# Altium schematic sheet CPU - USB, PCIE, ETHERNET.SchDoc
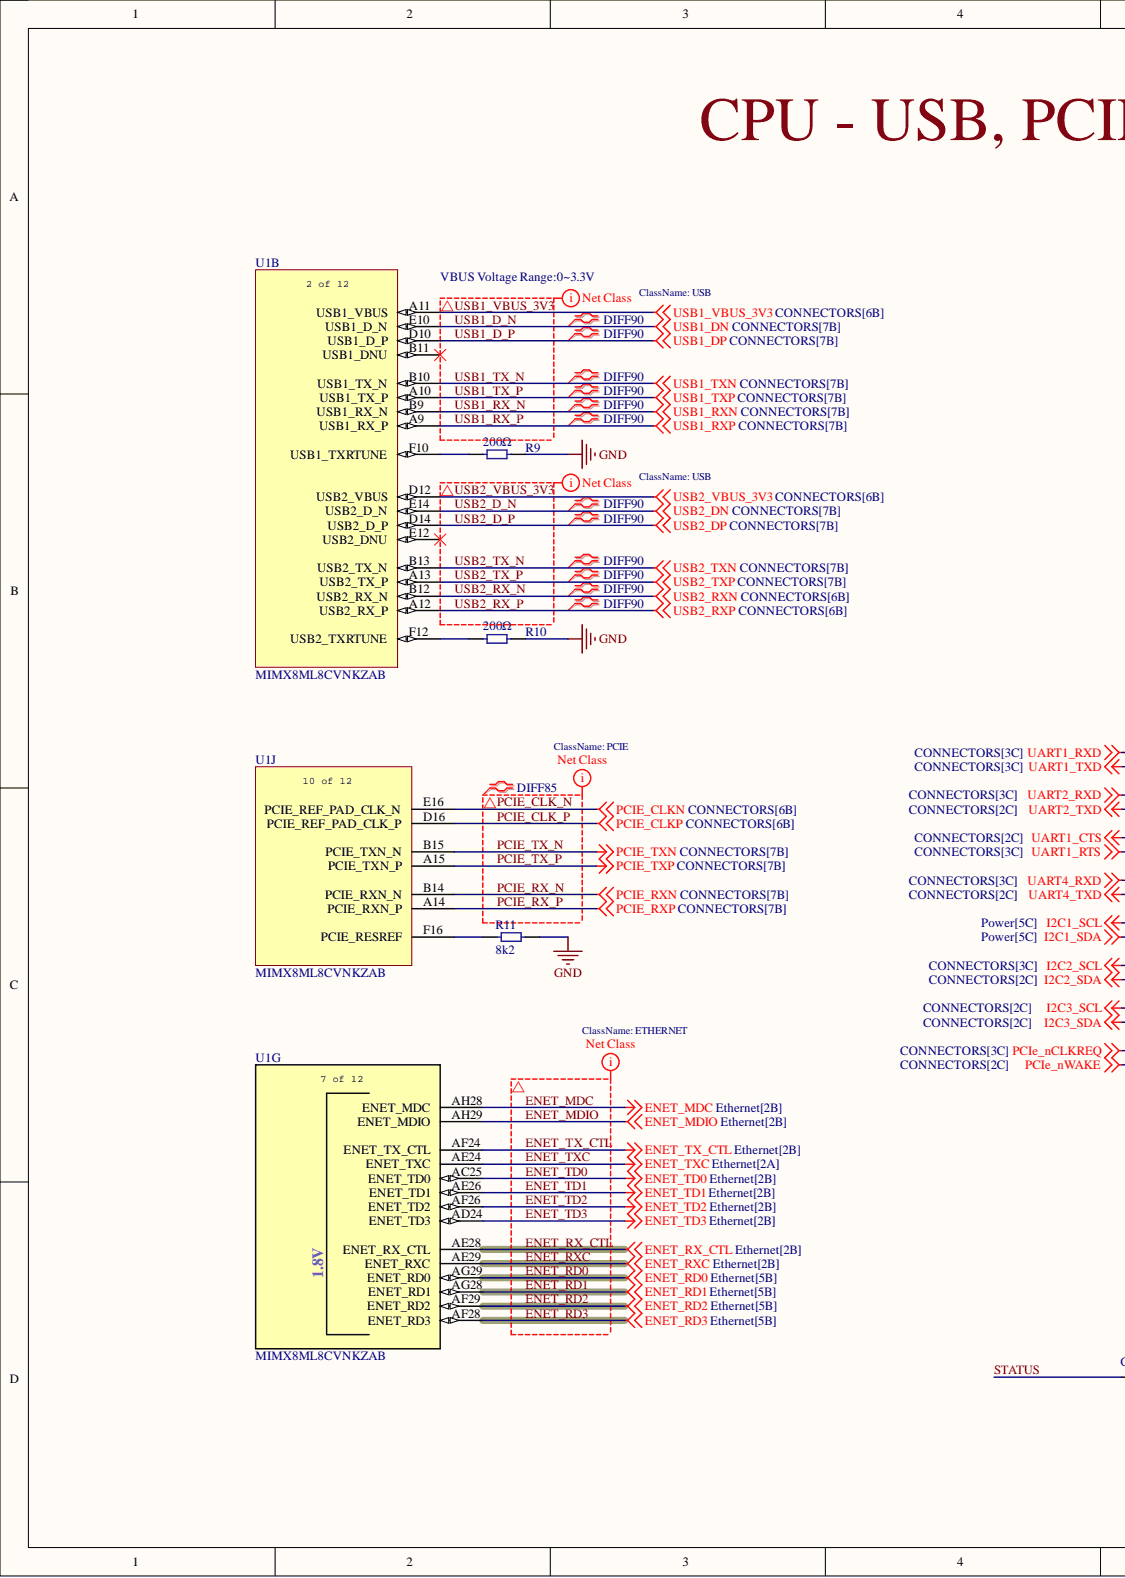
[diagram p0: CPU - USB, PCIE, ETHERNET.SchDoc, left]
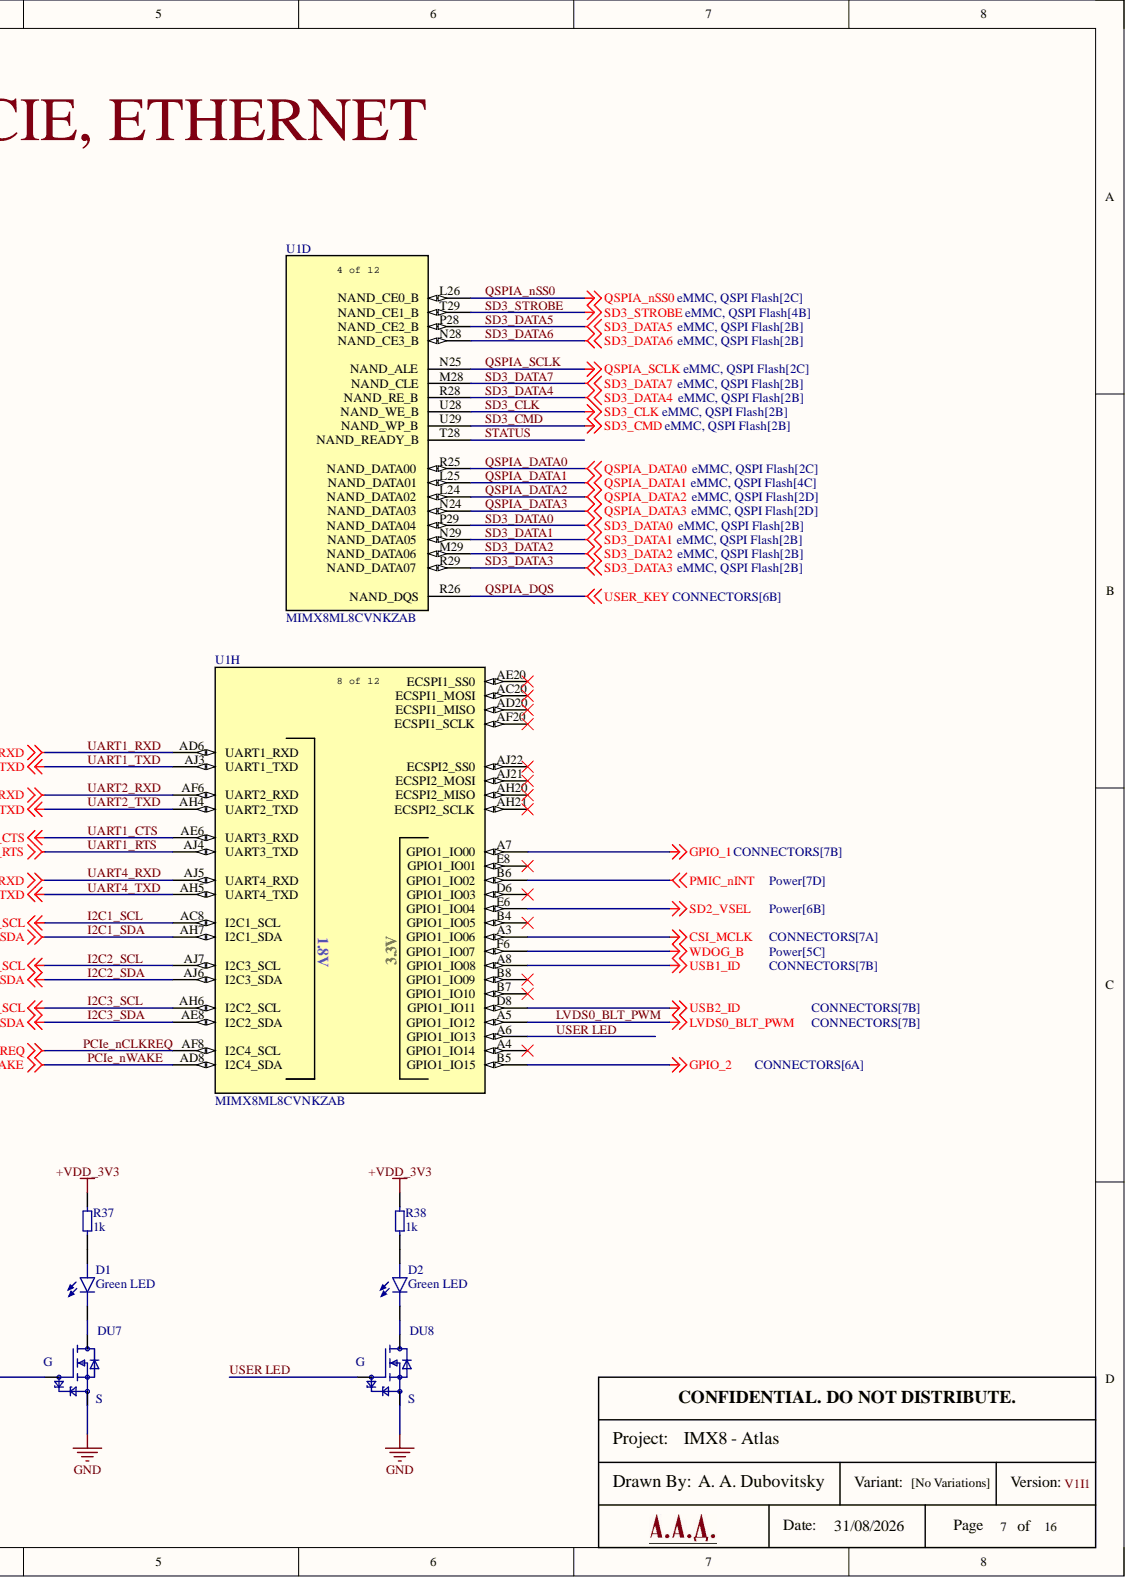
[diagram p1: CPU - USB, PCIE, ETHERNET.SchDoc, right]

## Parts
D1  Green LED  [LEDSC160X80X70L45N]
D2  Green LED  [LEDSC160X80X70L45N]
R9  200Ω  [RES 0201_0603]
R10  200Ω  [RES 0201_0603]
R11  8k2  [RES 0201_0603]
R37  1k  [RES 0603_1608]
R38  1k  [RES 0603_1608]
U1  MIMX8ML8CVNKZAB  [BGA548C50P29X29_1500X1500X148]  (parts B,D,G,H,J)
U7  (no value)  [SOT65P210X110-3N]
U8  (no value)  [SOT65P210X110-3N]

## Nets
+VDD_3V3: R37.1 R38.1
CSI_MCLK: U1.A3(GPIO1_IO06)
GND: R9.1 R10.1 R11.1 U7.2 U8.2
GPIO_1: U1.A7(GPIO1_IO00)
GPIO_2: U1.B5(GPIO1_IO15)
PMIC_nINT: U1.B6(GPIO1_IO02)
SD2_VSEL: U1.E6(GPIO1_IO04)
USB1_ID: U1.A8(GPIO1_IO08)
USB2_ID: U1.D8(GPIO1_IO11)
WDOG_B: U1.F6(GPIO1_IO07)
ENET_MDC: U1.AH28(ENET_MDC)
ENET_MDIO: U1.AH29(ENET_MDIO)
ENET_RD0: U1.AG29(ENET_RD0)
ENET_RD1: U1.AG28(ENET_RD1)
ENET_RD2: U1.AF29(ENET_RD2)
ENET_RD3: U1.AF28(ENET_RD3)
ENET_RX_CTL: U1.AE28(ENET_RX_CTL)
ENET_RXC: U1.AE29(ENET_RXC)
ENET_TD0: U1.AC25(ENET_TD0)
ENET_TD1: U1.AE26(ENET_TD1)
ENET_TD2: U1.AF26(ENET_TD2)
ENET_TD3: U1.AD24(ENET_TD3)
ENET_TX_CTL: U1.AF24(ENET_TX_CTL)
ENET_TXC: U1.AE24(ENET_TXC)
I2C1_SCL: U1.AC8(I2C1_SCL)
I2C1_SDA: U1.AH7(I2C1_SDA)
I2C2_SCL: U1.AJ7(I2C3_SCL)
I2C2_SDA: U1.AJ6(I2C3_SDA)
I2C3_SCL: U1.AH6(I2C2_SCL)
I2C3_SDA: U1.AE8(I2C2_SDA)
LVDS0_BLT_PWM: U1.A5(GPIO1_IO12)
PCIE_CLK_N: U1.E16(PCIE_REF_PAD_CLK_N)
PCIE_CLK_P: U1.D16(PCIE_REF_PAD_CLK_P)
PCIe_nCLKREQ: U1.AF8(I2C4_SCL)
PCIe_nWAKE: U1.AD8(I2C4_SDA)
PCIE_RX_N: U1.B14(PCIE_RXN_N)
PCIE_RX_P: U1.A14(PCIE_RXN_P)
PCIE_TX_N: U1.B15(PCIE_TXN_N)
PCIE_TX_P: U1.A15(PCIE_TXN_P)
QSPIA_DATA0: U1.R25(NAND_DATA00)
QSPIA_DATA1: U1.L25(NAND_DATA01)
QSPIA_DATA2: U1.L24(NAND_DATA02)
QSPIA_DATA3: U1.N24(NAND_DATA03)
QSPIA_DQS: U1.R26(NAND_DQS)
QSPIA_nSS0: U1.L26(NAND_CE0_B)
QSPIA_SCLK: U1.N25(NAND_ALE)
SD3_CLK: U1.U28(NAND_WE_B)
SD3_CMD: U1.U29(NAND_WP_B)
SD3_DATA0: U1.P29(NAND_DATA04)
SD3_DATA1: U1.N29(NAND_DATA05)
SD3_DATA2: U1.M29(NAND_DATA06)
SD3_DATA3: U1.R29(NAND_DATA07)
SD3_DATA4: U1.R28(NAND_RE_B)
SD3_DATA5: U1.P28(NAND_CE2_B)
SD3_DATA6: U1.N28(NAND_CE3_B)
SD3_DATA7: U1.M28(NAND_CLE)
SD3_STROBE: U1.T29(NAND_CE1_B)
STATUS: U1.T28(NAND_READY_B) U7.1
UART1_CTS: U1.AE6(UART3_RXD)
UART1_RTS: U1.AJ4(UART3_TXD)
UART1_RXD: U1.AD6(UART1_RXD)
UART1_TXD: U1.AJ3(UART1_TXD)
UART2_RXD: U1.AF6(UART2_RXD)
UART2_TXD: U1.AH4(UART2_TXD)
UART4_RXD: U1.AJ5(UART4_RXD)
UART4_TXD: U1.AH5(UART4_TXD)
USB1_D_N: U1.E10(USB1_D_N)
USB1_D_P: U1.D10(USB1_D_P)
USB1_RX_N: U1.B9(USB1_RX_N)
USB1_RX_P: U1.A9(USB1_RX_P)
USB1_TX_N: U1.B10(USB1_TX_N)
USB1_TX_P: U1.A10(USB1_TX_P)
USB1_VBUS_3V3: U1.A11(USB1_VBUS)
USB2_D_N: U1.E14(USB2_D_N)
USB2_D_P: U1.D14(USB2_D_P)
USB2_RX_N: U1.B12(USB2_RX_N)
USB2_RX_P: U1.A12(USB2_RX_P)
USB2_TX_N: U1.B13(USB2_TX_N)
USB2_TX_P: U1.A13(USB2_TX_P)
USB2_VBUS_3V3: U1.D12(USB2_VBUS)
USER LED: U1.A6(GPIO1_IO13) U8.1
NetD1_A: D1.A R37.2
NetD1_C: D1.C U7.3
NetD2_A: D2.A R38.2
NetD2_C: D2.C U8.3
NetR9_2: R9.2 U1.F10(USB1_TXRTUNE)
NetR10_2: R10.2 U1.F12(USB2_TXRTUNE)
NetR11_2: R11.2 U1.F16(PCIE_RESREF)

Not connected: U1 A4(GPIO1_IO14) AC20(ECSPI1_MOSI) AD20(ECSPI1_MISO) AE20(ECSPI1_SS0) AF20(ECSPI1_SCLK) AH20(ECSPI2_MISO) AH21(ECSPI2_SCLK) AJ21(ECSPI2_MOSI) AJ22(ECSPI2_SS0) B4(GPIO1_IO05) B7(GPIO1_IO10) B8(GPIO1_IO09) B11(USB1_DNU) D6(GPIO1_IO03) E8(GPIO1_IO01) E12(USB2_DNU)

## Library data of the parts
D1 D2 = C6695603: LED 0603 SMD Fwd Current 25mA Green Clear Lens 20 mcd 598 Series | Dialight 598-8070-107F; Check_prices: https://www.snapeda.com/parts/598-8070-107F/Dialight/view-part/?ref=eda; Component Kind: Standard; MF: Dialight; MP: 598-8070-107F; Package: 0603 Dialight; SnapEDA_Link: https://www.snapeda.com/parts/598-8070-107F/Dialight/view-part/?ref=snap; Library Name: Atlas - Symbols.SchLib
R9 R10 = C270359: Res. 200Ω 50V 50mW ±1% 0201; Component Kind: Standard; Library Name: Atlas - Symbols.SchLib
R11 = C473470: Res. 8.2kΩ 50mW ±1% 0201; Component Kind: Standard; Library Name: Atlas - Symbols.SchLib
R37 R38 = FRC0603F1001TS: Res. 1kΩ, 100mW, ±1%; .PCB_Checked: A.A.D.; Component Kind: Standard; .Created: A.A.D.; .SCH_Checked: A.A.D.; Library Name: Atlas - Symbols.SchLib
U1 = MIMX8ML8CVNKZAB: IC ARM MCU; Manufacturer_Name: NXP; Component Kind: Standard; Manufacturer_Part_Number: MIMX8ML8CVNKZAB; RefDes: RefDes; Type: Type; Copyright: Copyright (C) 2026 Ultra Librarian. All rights reserved.; Library Name: Atlas - Symbols.SchLib
U7 U8 = C282548: N-Channel 30 V 350mA (Ta) 260mW (Ta), 830mW (Tc) Surface Mount SOT-323; MF: Nexperia USA; MP: NX3008NBKW,115; Check_prices: https://www.snapeda.com/parts/NX3008NBKW,115/Nexperia/view-part/?ref=eda; Component Kind: Standard; Package: SC-70-3 Nexperia USA Inc.; SnapEDA_Link: https://www.snapeda.com/parts/NX3008NBKW,115/Nexperia/view-part/?ref=snap; Library Name: Atlas - Symbols.SchLib
